# Altium schematic sheet Sonyc_Mic.SchDoc
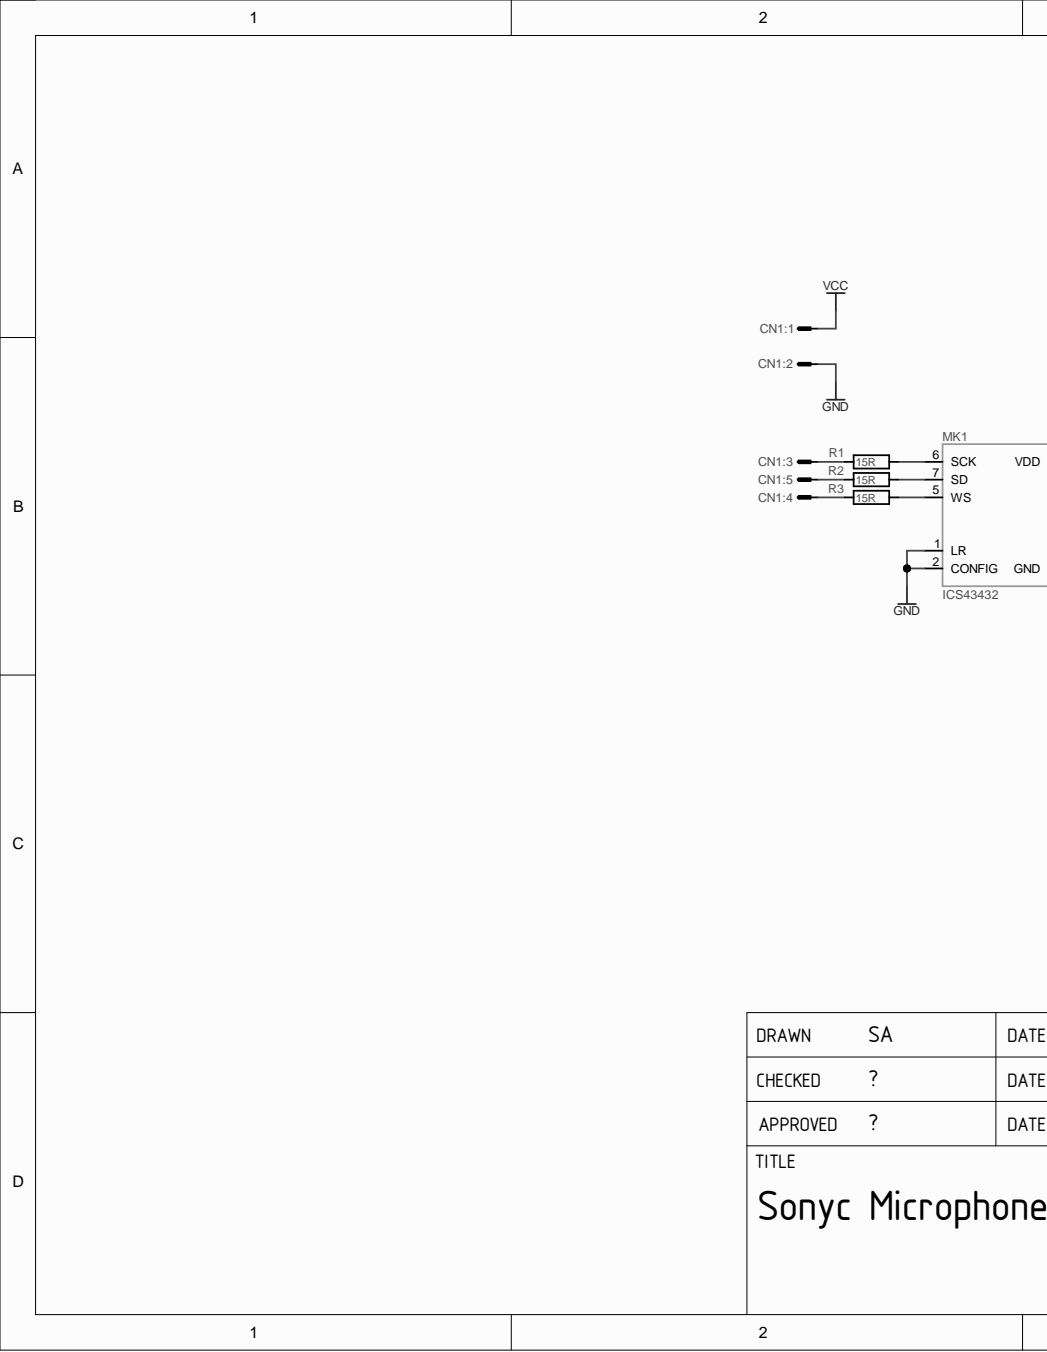
[diagram p0: Sonyc_Mic.SchDoc, left]
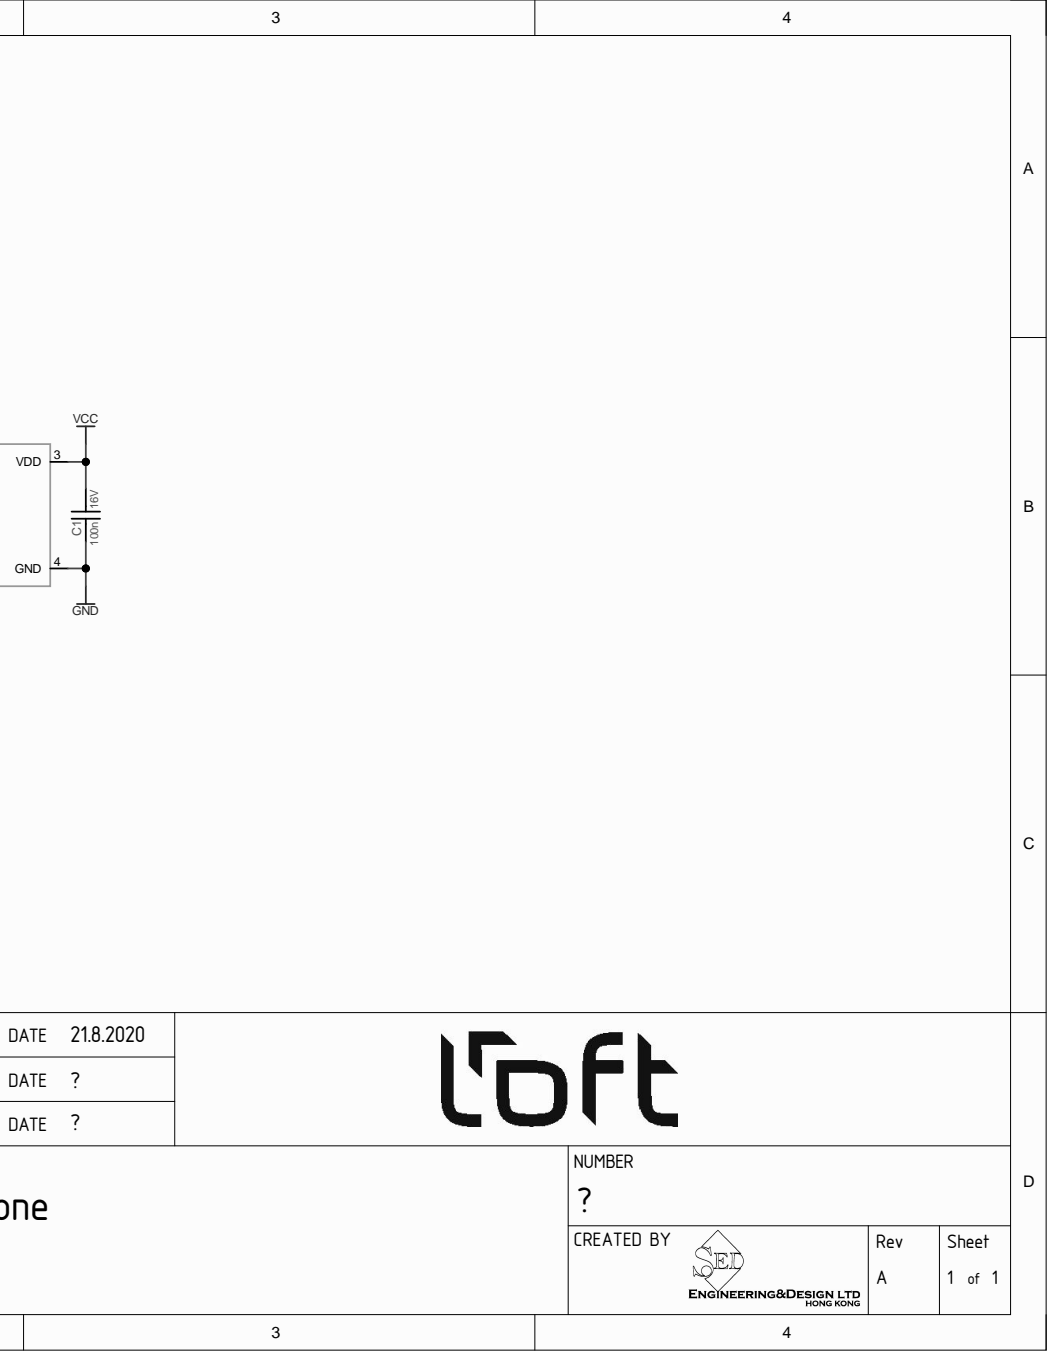
[diagram p1: Sonyc_Mic.SchDoc, right]

## Parts
C1  100n  _P1: 16V  [0402CAP]
CN1  BM05B-SRSS-TB  [CON5_BM05B-SRSS-TB]  (value not drawn)  (parts :1,:2,:3,:4,:5)
MK1  ICS43432  [ICS43432]
R1  15R  [0402]
R2  15R  [0402]
R3  15R  [0402]

## Nets
GND: C1.1 CN1.2 MK1.1(LR) MK1.2(CONFIG) MK1.4(GND)
VCC: C1.2 CN1.1 MK1.3(VDD)
NetCN1_3: CN1.3 R1.1
NetCN1_4: CN1.4 R3.1
NetCN1_5: CN1.5 R2.1
NetMK1_5: MK1.5(WS) R3.2
NetMK1_6: MK1.6(SCK) R1.2
NetMK1_7: MK1.7(SD) R2.2

## Library data of the parts
C1 = CAP0402-100n-16V-±10%-X7R: CAP 0402 CERAMIC 100n 16V ±10% X7R; Database Table Name: SMD CAP 0402; Component Kind: Standard; Component Type: Standard; Library Name: SVN_Discretes.SVNDBLib; Library Part Reference: CAP0402-100n-16V-±10%-X7R; Tolerance: ±10%; Type: X7R; Value: 100n; Voltage: 16V
CN1 = CON5_BM05B-SRSS-TB: JST Connector Header Surface Mount 5 position 0.039" (1.00mm); Database Table Name: Connectors - General; _Comment: =Value; Component Kind: Standard; Component Type: Standard; Library Name: SVN_Connectors.SVNDBLib; Pin Count: 5; UID: CON5_BM05B-SRSS-TB
MK1 = ICS43432: MICROPHONE MEMS DIGITAL I2S OMNI; Manufacturer: TDK; Database Table Name: Main; Component Kind: Standard; Component Type: Standard; Library Name: SVN_Main.SVNDBLib; UID: ICS43432; Value: ICS43432
R1-R3 = RES0402-0.063W-±1%-15R: RES 0402 0.063W ±1% THICK FILM; Manufacturers Part Number: VISHAY D/CRCW; Database Table Name: SMD RES 0402; Voltage: 50V; Component Kind: Standard; Component Type: Standard; Library Name: SVN_Discretes.SVNDBLib; Library Part Reference: RES0402-0.063W-±1%-15R; Power: 0.063W; Tolerance: ±1%; Type: THICK FILM; Value: 15R
